# Altium schematic sheet MainBoard_MCU_RF.SchDoc
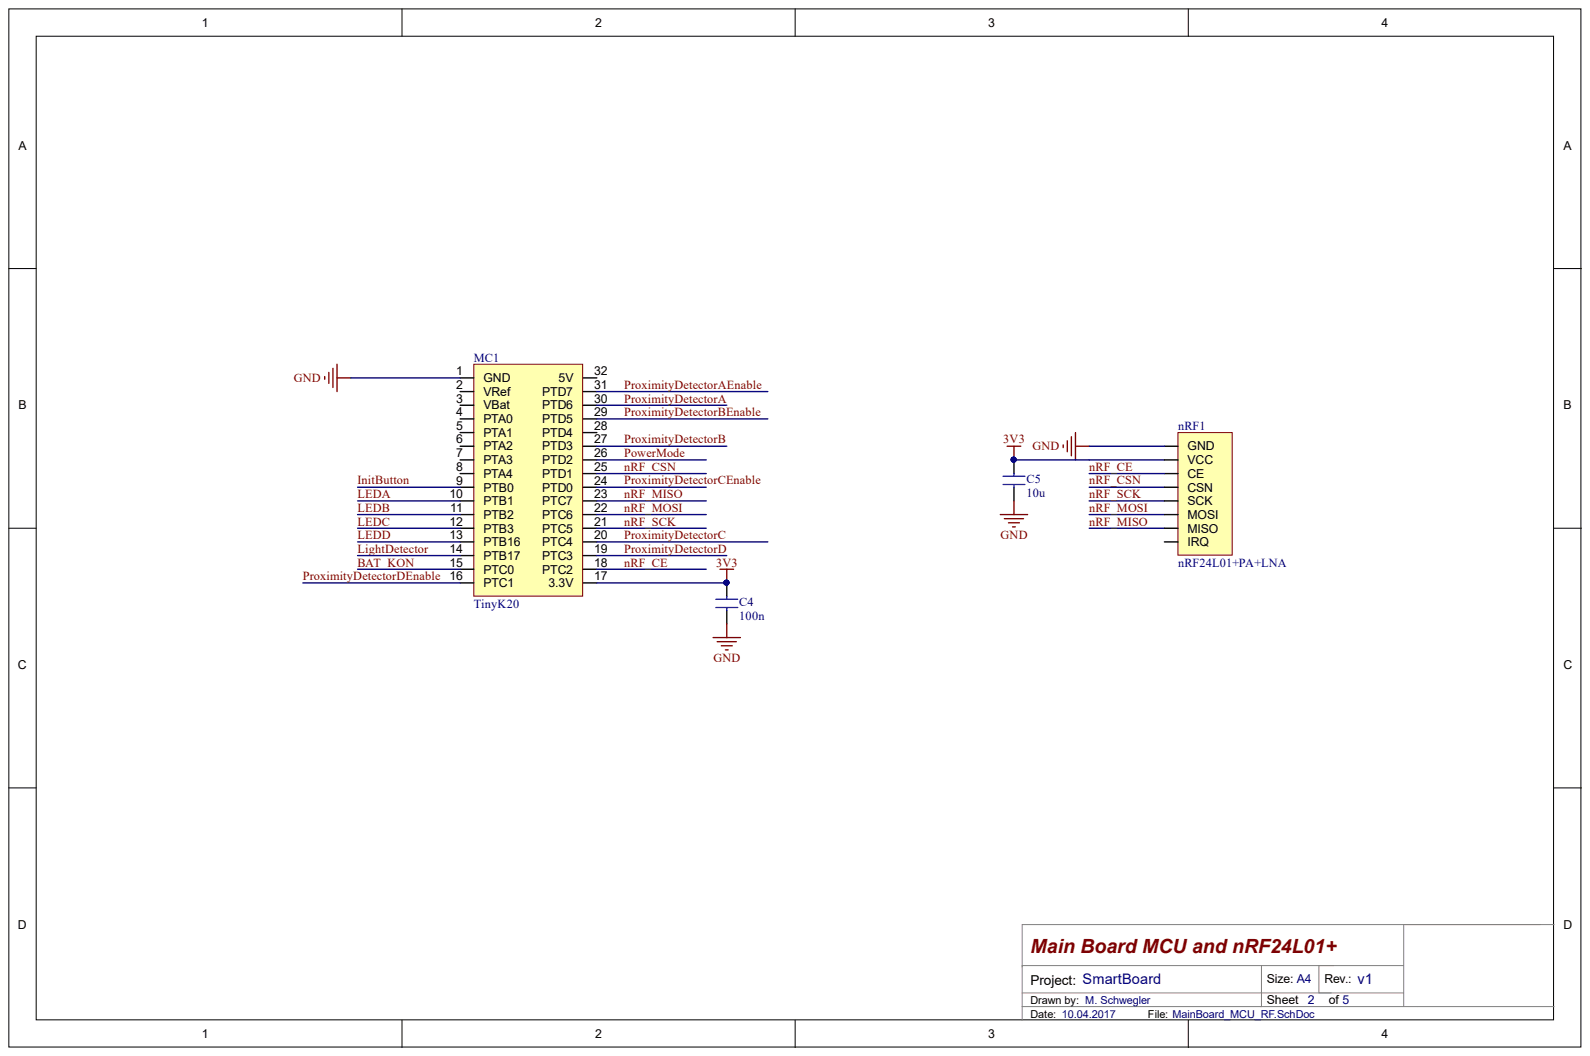
[diagram p0: MainBoard_MCU_RF.SchDoc]

## Parts
C4  100n  [0805_C]
C5  10u  [0805_C]
MC1  TinyK20  [DIP32]
nRF1  nRF24L01+PA+LNA  [CON_THT_2x4P_RM2.54_Stiftl]

## Nets
3V3: C4.2 C5.2 MC1.17(3.3V) nRF1.2(VCC)
GND: C4.1 C5.1 MC1.1(GND) nRF1.1(GND)
BAT_KON: MC1.15(PTC0)
INITBUTTON: MC1.9(PTB0)
LEDA: MC1.10(PTB1)
LEDB: MC1.11(PTB2)
LEDC: MC1.12(PTB3)
LEDD: MC1.13(PTB16)
LIGHTDETECTOR: MC1.14(PTB17)
NRF_CE: MC1.18(PTC2) nRF1.3(CE)
NRF_CSN: MC1.25(PTD1) nRF1.4(CSN)
NRF_MISO: MC1.23(PTC7) nRF1.7(MISO)
NRF_MOSI: MC1.22(PTC6) nRF1.6(MOSI)
NRF_SCK: MC1.21(PTC5) nRF1.5(SCK)
POWERMODE: MC1.26(PTD2)
PROXIMITYDETECTORA: MC1.30(PTD6)
PROXIMITYDETECTORAENABLE: MC1.31(PTD7)
PROXIMITYDETECTORB: MC1.27(PTD3)
PROXIMITYDETECTORBENABLE: MC1.29(PTD5)
PROXIMITYDETECTORC: MC1.20(PTC4)
PROXIMITYDETECTORCENABLE: MC1.24(PTD0)
PROXIMITYDETECTORD: MC1.19(PTC3)
PROXIMITYDETECTORDENABLE: MC1.16(PTC1)

Not connected: MC1 2(VRef) 3(VBat) 4(PTA0) 5(PTA1) 6(PTA2) 7(PTA3) 8(PTA4) 28(PTD4) 32(5V); nRF1 8(IRQ)

## Library data of the parts
C4 C5 = C?: Normaler Kondensator; Component Kind: Standard; Library Name: MainBoard.SCHLIB
nRF1 = Bluefruit: Component Kind: Standard; Library Name: MainBoard.SCHLIB
MC1 = TinyK20: Component Kind: Standard; Library Name: MainBoard.SCHLIB
